# Altium schematic sheet Sheet.SchDoc
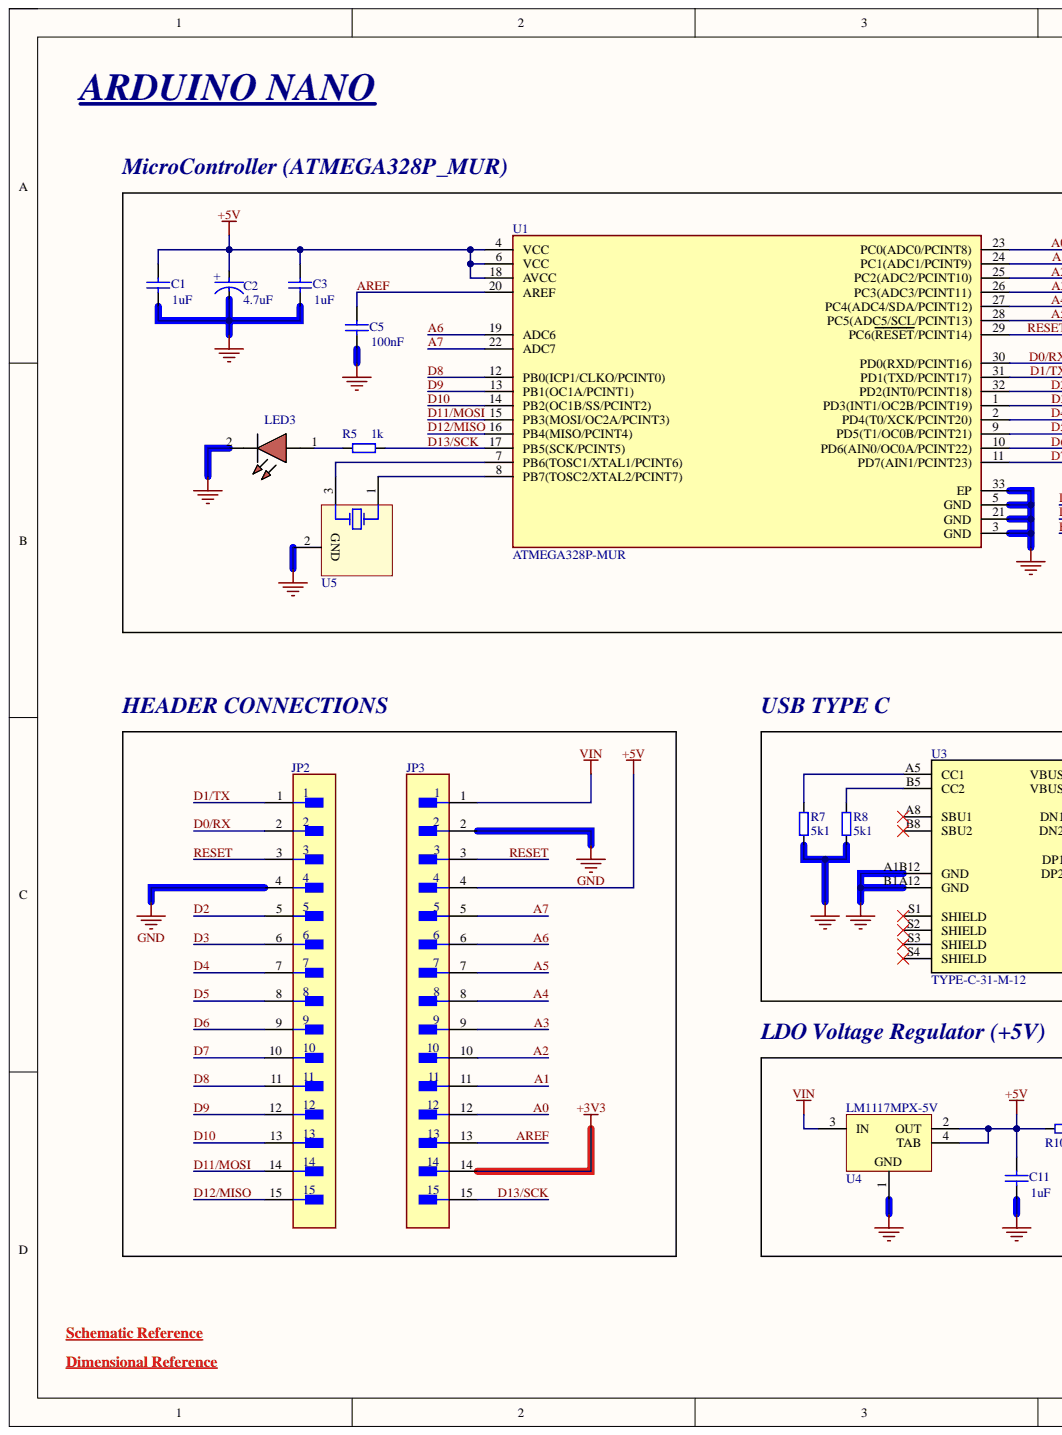
[diagram p0: Sheet.SchDoc, left]
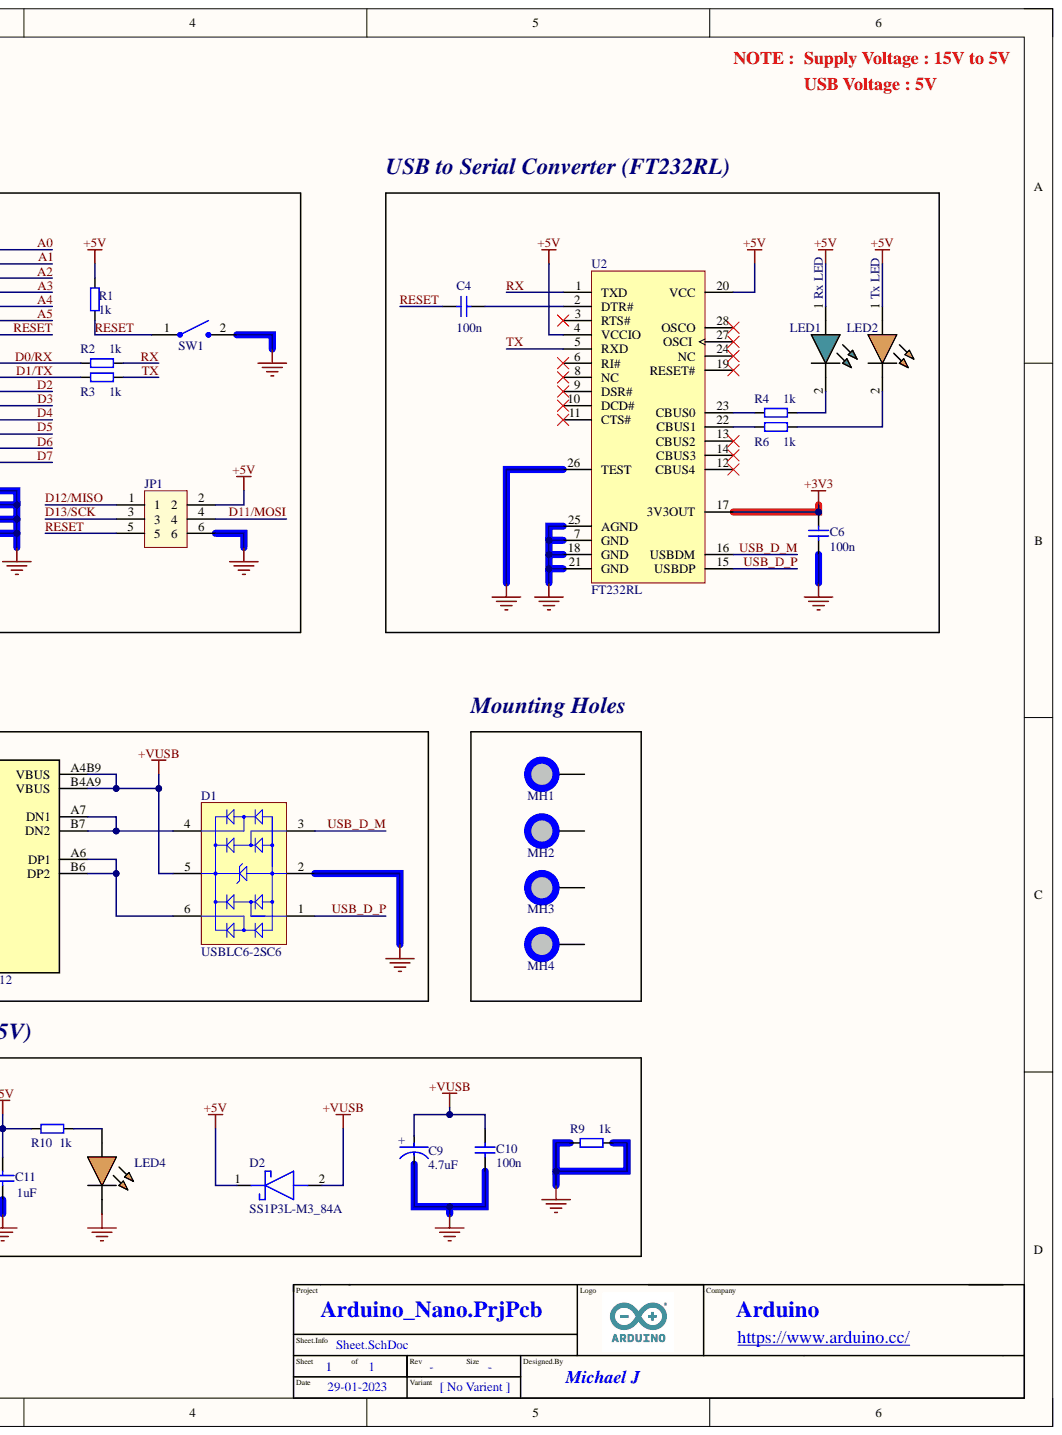
[diagram p1: Sheet.SchDoc, right]

## Parts
C1  value 1uF  [0402]  (hidden comment: C0402)
C2  4.7uF  [FP-T491B475K016AT-MFG]
C3  value 1uF  [0402]  (hidden comment: C0402)
C4  100n  [CAP_0402_16V]
C5  value 100nF  [0402]  (hidden comment: C0402)
C6  100n  [CAP_0402_16V]
C9  4.7uF  [FP-T491B475K016AT-MFG]
C10  100n  [CAP_0402_16V]
C11  value 1uF  [0402]  (hidden comment: C0402)
D1  USBLC6-2SC6  [STM-SOT23-6L_V]
D2  SS1P3L-M3_84A  [SS1P3LM384A]
JP1  Header_Male 2x03 2.54mm  [Header_Male 2x03 2.54mm]  (value not drawn)
JP2  TSW-115-07-G-S  [FP-TSW-115-07-G-S-MFG]  (value not drawn)
JP3  TSW-115-07-G-S  [FP-TSW-115-07-G-S-MFG]  (value not drawn)
LED1  LED_0402_GREEN  [LED_0402]  (value not drawn)
LED2  LED_0402_ORANGE  [LED_0402]  (value not drawn)
LED3  LED_0402_ORANGE  [LED_0402]  (value not drawn)
LED4  LED_0402_ORANGE  [LED_0402]  (value not drawn)
MH1  Mounting_Hole_NP_7.4mm_3.2mmNPD  [Mounting_Hole_NP_7.4mm_3.2mmNPD]  (value not drawn)  (no BOM)
MH2  Mounting_Hole_NP_7.4mm_3.2mmNPD  [Mounting_Hole_NP_7.4mm_3.2mmNPD]  (value not drawn)  (no BOM)
MH3  Mounting_Hole_NP_7.4mm_3.2mmNPD  [Mounting_Hole_NP_7.4mm_3.2mmNPD]  (value not drawn)  (no BOM)
MH4  Mounting_Hole_NP_7.4mm_3.2mmNPD  [Mounting_Hole_NP_7.4mm_3.2mmNPD]  (value not drawn)  (no BOM)
R1  1k  [RES_0402]
R2  1k  [RES_0402]
R3  1k  [RES_0402]
R4  1k  [RES_0402]
R5  1k  [RES_0402]
R6  1k  [RES_0402]
R7  5k1  [RES_0402]
R8  5k1  [RES_0402]
R9  1k  [RES_0402]
R10  1k  [RES_0402]
SW1  SMD,3x4x2.5mm Tactile Switches ROHS  [SMD,3x4x2.5mm Tactile Switches ROHS]  (value not drawn)
U1  ATMEGA328P-MUR  [FP-32M1-A-IPC_C]
U2  FT232RL  [FTDI-SSOP-28_M]
U3  TYPE-C-31-M-12  [TYPE-C-31-M-12]
U4  LM1117MPX-5V  [DCY0004A_L]
U5  CSTCE16M0V53-R0  [MURA-CSTCE_V-3_V]  (value not drawn)

## Nets
+3V3: C6.2 JP3.14 U2.17(3V3OUT)
+5V: C1.2 C2.2 C3.2 C11.2 D2.1 JP1.2 JP3.4 LED1.1 LED2.1 R1.2 R10.2 U1.4(VCC) U1.6(VCC) U1.18(AVCC) U2.4(VCCIO) U2.20(VCC) U4.2(OUT) U4.4(TAB)
+VUSB: C9.2 C10.1 D1.5 D2.2 U3.A4B9(VBUS) U3.B4A9(VBUS)
GND: C1.1 C2.1 C3.1 C5.1 C6.1 C9.1 C10.2 C11.1 D1.2 JP1.6 JP2.4 JP3.2 LED3.2 LED4.2 R7.1 R8.1 R9.1 R9.2 SW1.2 U1.3(GND) U1.5(GND) U1.21(GND) U1.33(EP) U2.7(GND) U2.18(GND) U2.21(GND) U2.25(AGND) U2.26(TEST) U3.A1B12(GND) U3.B1A12(GND) U4.1 U5.2
VIN: JP3.1 U4.3(IN)
A0: JP3.12 U1.23(PC0(ADC0/PCINT8))
A1: JP3.11 U1.24(PC1(ADC1/PCINT9))
A2: JP3.10 U1.25(PC2(ADC2/PCINT10))
A3: JP3.9 U1.26(PC3(ADC3/PCINT11))
A4: JP3.8 U1.27(PC4(ADC4/SDA/PCINT12))
A5: JP3.7 U1.28(PC5(ADC5/SCL/PCINT13))
A6: JP3.6 U1.19(ADC6)
A7: JP3.5 U1.22(ADC7)
AREF: C5.2 JP3.13 U1.20(AREF)
D0/RX: JP2.2 R2.2 U1.30(PD0(RXD/PCINT16))
D1/TX: JP2.1 R3.2 U1.31(PD1(TXD/PCINT17))
D2: JP2.5 U1.32(PD2(INT0/PCINT18))
D3: JP2.6 U1.1(PD3(INT1/OC2B/PCINT19))
D4: JP2.7 U1.2(PD4(T0/XCK/PCINT20))
D5: JP2.8 U1.9(PD5(T1/OC0B/PCINT21))
D6: JP2.9 U1.10(PD6(AIN0/OC0A/PCINT22))
D7: JP2.10 U1.11(PD7(AIN1/PCINT23))
D8: JP2.11 U1.12(PB0(ICP1/CLKO/PCINT0))
D9: JP2.12 U1.13(PB1(OC1A/PCINT1))
D10: JP2.13 U1.14(PB2(OC1B/SS/PCINT2))
D11/MOSI: JP1.4 JP2.14 U1.15(PB3(MOSI/OC2A/PCINT3))
D12/MISO: JP1.1 JP2.15 U1.16(PB4(MISO/PCINT4))
D13/SCK: JP1.3 JP3.15 R5.1 U1.17(PB5(SCK/PCINT5))
RESET: C4.1 JP1.5 JP2.3 JP3.3 R1.1 SW1.1 U1.29(PC6(RESET/PCINT14))
RX: R2.1 U2.1(TXD)
TX: R3.1 U2.5(RXD)
USB_D_M: D1.3 U2.16(USBDM)
USB_D_P: D1.1 U2.15(USBDP)
NetC4_2: C4.2 U2.2(DTR#)
NetD1_4: D1.4 U3.A7(DN1) U3.B7(DN2)
NetD1_6: D1.6 U3.A6(DP1) U3.B6(DP2)
NetLED1_2: LED1.2 R4.1
NetLED2_2: LED2.2 R6.1
NetLED3_1: LED3.1 R5.2
NetLED4_1: LED4.1 R10.1
NetR4_2: R4.2 U2.23(CBUS0)
NetR6_2: R6.2 U2.22(CBUS1)
NetR7_2: R7.2 U3.A5(CC1)
NetR8_2: R8.2 U3.B5(CC2)
NetU1_7: U1.7(PB6(TOSC1/XTAL1/PCINT6)) U5.3
NetU1_8: U1.8(PB7(TOSC2/XTAL2/PCINT7)) U5.1

Not connected: MH1 1; MH2 1; MH3 1; MH4 1; U2 3(RTS#) 6(RI#) 8(NC) 9(DSR#) 10(DCD#) 11(CTS#) 12(CBUS4) 13(CBUS2) 14(CBUS3) 19(RESET#) 24(NC) 27(OSCI) 28(OSCO); U3 A8(SBU1) B8(SBU2) S1(SHIELD) S2(SHIELD) S3(SHIELD) S4(SHIELD)

## Library data of the parts
C1 C3 C5 C11 = C0402: Ceramic Chip Capacitor - Standard; Note: Footprint corresponds to dimensions for bulk cassette packaging. This case size has tightened tolerances.; Code_IPC: 1005 [0402]; Code_JEITA: 0402; Component Kind: Standard; ComponentLink1Description: Manufacturer Link; ComponentLink1URL: http://www.kemet.com/; ComponentLink2Description: Datasheet; ComponentLink2URL: http://www.kemet.com/kemet/web/homepage/kechome.nsf/vapubfilesname/F3102TA.pdf/$file/F3102TA.pdf; DatasheetDocument: Mar-2001; LatestRevisionDate: 19-Mar-2004; LatestRevisionNote: Silkscreen size reduced.; Library Name: KEMET Chip Capacitor.IntLib; PackageDocument: Mar-2001; PackageReference: 0402; Published: 17-Jul-2002; Signal Integrity: C0402; Simulation: CAP; Publisher: Altium Limited
C2 C9 = CMP-03017-000048-1: CAP TANT 4.7UF 10% 16V 1411, T491B475K016AT; Dielectric Material: Tantalum; Dissipation Factor: 6%; ESR (Equivalent Series Resistance): 3.5R; Leakage Current: 800nA; Life (Hours): 2000Hour; Polarity: Polar; Tolerance: 20%; Capacitance: 4.7uF; Case Code (Imperial): 1411; Case Code (Metric): 3528; Case/Package: 1411; Component Kind: Standard; Depth: 2.8mm; Features: General Purpose; Height: 1.9mm; Height - Seated (Max): 0.083inch; Lead Free: Lead Free; Lead Pitch: 1.9mm; Length: 3.5mm; Library Name: Altium Content Vault; Max Operating Temperature: 125°C; Min Operating Temperature: -55°C; Mount: Surface Mount; Number of Pins: 2; Package Quantity: 1; Packaging: Tape and Reel; Radiation Hardening: No; REACH SVHC: No SVHC; RoHS Compliant: Yes; Termination: SMD/SMT; Voltage: 16V; Voltage Rating: 16V; Voltage Rating (DC): 16V; Width: 2.8mm
C4 C6 C10 = CAP_0402_16V: Capacitor SMD 0402 16V; Component Kind: Standard; Library Name: Prezence_SMD.IntLib
D1 = CMP-2000-05378-1: Very low capacitance ESD protection, 3.5 pF, -40 to 125 degC, 6-Pin SOT23, RoHS, Tape and Reel; Manufacturer: STMicroelectronics; Capacitance Value: 3.5pF; Clamping Voltage: 17V; Configuration: Dual; Direction Type: Uni-Directional; Leakage Current: 0.15uA; Number of Elements: 1; Peak Pulse Current: 5A; Product Depth (mm): 1.75mm; Product Height (mm): 1.3mm; Product Length (mm): 3.05mm; Rad Hardened: No; Reverse Breakdown Voltage: 6V; Reverse Stand-off Voltage: 5V; Suppressor Type: DiodeArrays; Test Current (It): 1mA; Clamping Voltage (Max): 17V; Component Kind: Standard; Datasheet URL: http://www.st.com/st-web-ui/static/active/en/resource/technical/document/datasheet/CD00050750.pdf; Datasheet Version: Rev. 5, 10/2011; Leakage Current (Max): 0.15uA; Library Name: Altium Content Vault; Manufacturer URL: http://www.st.com/; Mounting: SurfaceMount; Mounting Style: SurfaceMount; Operating Temp Range: -40Cto125C; Operating Temperature Classification: Automotive; Operating Temperature Max Deg. C: 125C; Operating Temperature Min Deg. C: -40C; Package / Case: SOT-23; Package Description: 6-Pin Small Outline Transistor, Body 2.925 x 1.625 mm, Pitch 0.95 mm; Package Reference: SOT23-6L; Packaging: TapeandReel; Pin Count: 6; Polarity: Uni-Directional; Working Voltage: 5V; Working Voltage (Max): 5V
D2 = SS1P3L-M3_84A: Schottky Diode; Manufacturer_Name: Vishay; Manufacturer_Part_Number: SS1P3L-M3/84A; Arrow Part Number: SS1P3L-M3/84A; Arrow Price/Stock: https://www.arrow.com/en/products/ss1p3l-m384a/vishay?region=nac; Component Kind: Standard; Datasheet Link: https://ms.componentsearchengine.com/Datasheets/2/SS1P3L-M3_84A.pdf; Height: 1.15mm; Mouser Part Number: 625-SS1P3L-M3/84A; Mouser Price/Stock: https://www.mouser.co.uk/ProductDetail/Vishay-General-Semiconductor/SS1P3L-M3-84A?qs=HSPD0Bff7iZtYrbeCvAZDQ%3D%3D
JP1 = Header_Male 2x03 2.54mm: Conn Unshrouded Header HDR 6 POS 2.54mm Solder ST Thru-Hole; Manufacturer: Samtec; Manufacturer Part Number: TSW-103-07-F-D; Connector Type: Male Pin; Gender: Male; Max Current Rating: 7.6A; Max Voltage Rating (AC): 550V; Number of Contacts: 6; Row Spacing: 0.1inch; Category: Headers and Wire Housings; Color: Black; Component Kind: Standard; ComponentLink1Description: https://datasheet.ciiva.com/739/tsw-xxx-xx-xxx-x-xx-xxx-mkt-739891.pdf?src-supplier=Digi-Key; ComponentLink1URL: https://datasheet.ciiva.com/739/tsw-xxx-xx-xxx-x-xx-xxx-mkt-739891.pdf?src-supplier=Digi-Key; ComponentLink2Description: https://datasheet.ciiva.com/16722/htsw-th-16722939.pdf?src-supplier=Avnet+Europe; ComponentLink2URL: https://datasheet.ciiva.com/16722/htsw-th-16722939.pdf?src-supplier=Avnet+Europe; Contact Material: Bronze; Contact Mating Length: 0.23inch; Contact Plating: Gold; Contact Type: Male Pin; Depth: 5.08mm; Housing Color: Black; Housing Material: Polyester; Length: 7.62mm; Library Name: Arduino_Uno_V1.1.IntLib; Max Operating Temperature: 125°C; Min Operating Temperature: -55°C; Mount: Through Hole; Number of Positions: 6; Number of Rows: 2; Orientation: Straight; Packaging: Bulk; Pitch: 2.54mm; Pricing 1: 1=0.71000, 10=0.66700, 100=0.51110, 500=0.44440, 1000=0.36663, 5000=0.32219, 10000=0.29997 (USD); Radiation Hardening: No; REACH SVHC: No SVHC; RoHS: Yes; RoHS Compliant: Yes; Series: TSW; Stock 1: 13135; Supplier 1: Digi-Key; Supplier Part Number 1: SAM10846-ND; Termination: Solder
JP2 JP3 = CMP-02766-000090-1: CONN HEADER VERT 15POS 2.54MM; Manufacturer: SAMTEC; Conn Gender: Male; Conn Orientation: Vertical; Number Of Contacts: 15; Automotive: No; Category: Conn; Component Kind: Standard; ComponentLink2Description: Datasheet; ComponentLink2URL: http://suddendocs.samtec.com/catalog_english/tsw_th.pdf; Contact Material: Gold,Nickel; Device Class L1: Connectors; Device Class L2: Headers and Wire Housings; Device Class L3: unset; Digikey Description: CONN HEADER VERT 15POS 2.54MM; Footprint Url: http://suddendocs.samtec.com/prints/tsw-xxx-xx-x-x-xx-xxx-footprint.pdf; Height: 8.71mm; Lead Free: Yes; Library Name: Altium Content Vault; Manufacturer Part Number: TSW-115-07-G-S; Number Of Rows: 1; Octopart: https://octopart.com/tsw-115-07-g-s-samtec-272210; Package: TSW-115-07-G-S; Pitch: 2.54mm; RoHS: TRUE; Temperature: -55°C to +125°C; Temperature Range High: +125°C; Temperature Range Low: -55°C
LED1 = LED_0402_GREEN: LED SMD 0402 Green; Manufacturer_Name: Kingbright; Manufacturer_Part_Number: APHHS1005LZGCK-V; Arrow Part Number: APHHS1005LZGCK-V; Arrow Price/Stock: https://www.arrow.com/en/products/aphhs1005lzgck-v/kingbright; Component Kind: Standard; Datasheet Link: https://www.kingbrightusa.com/images/catalog/SPEC/APHHS1005LZGCK-V.pdf; Height: 0.6mm; Mouser Part Number: N/A; Mouser Price/Stock: https://www.mouser.co.uk/ProductDetail/Kingbright/APHHS1005LZGCK-V?qs=HXFqYaX1Q2zaZg1%252BPZjK%2FQ%3D%3D; Library Name: Prezence_SMD.IntLib
LED2-LED4 = LED_0402_ORANGE: LED SMD 0402 Orange; Component Kind: Standard; Library Name: Prezence_SMD.IntLib
MH1-MH4 = Mounting_Hole_NP_7.4mm_3.2mmNPD: Mounting_Hole_NonPlated_7.4mm_3.2mmNonPlatedDrill; Library Name: Prezence_SMD.IntLib
R1-R6 R9 R10 = RES_0402_2: Resistor SMD 0402; Component Kind: Standard; Library Name: Prezence_SMD.IntLib
R7 R8 = RES_0402_3: Resistor SMD 0402; Component Kind: Standard; Library Name: Prezence_SMD.IntLib
U1 = CMP-06276-000062-1: IC MCU 8BIT 32KB FLASH 32VQFN; Core Architecture: PIC; Frequency: 20MHz; Interface: I2C; Memory Size: 3.5KB; Number of I/Os: 24; Oscillator Type: Internal; Peripherals: Brown-out Detect/Reset; Case/Package: VQFN; Component Kind: Standard; Library Name: Altium Content Vault; Max Operating Temperature: 125°C; Memory Type: FLASH; Min Operating Temperature: -40°C; Packaging: Rail/Tube
U2 = CMP-2000-05029-1: IC USB FS SERIAL UART 28-SSOP; Manufacturer: FTDI; Maximum Data Rate: 3MBd; Number of Channels per Chip: 1; Receive FIFO: 256B; Transmit FIFO: 128B; Component Kind: Standard; Datasheet URL: http://datasheet.octopart.com/FT232RL-FTDI-datasheet-120501.pdf; Datasheet Version: Rev. 1.04, 02/2006; Dimensions: 10.2x5.3x1.75mm; Height: 1.75mm; Length: 10.2mm; Library Name: Altium Content Vault; Manufacturer URL: http://www.ftdichip.com/; Maximum Operating Temperature: +85degC; Minimum Operating Temperature: -40degC; Mounting Type: SurfaceMount; Package Type: SSOP; Pin Count: 28; Width: 5.3mm
U3 = USB_Type_C: Component Kind: Standard; Description: TYPE-C-31-M-12; Library Name: Prezence_Final.IntLib
U4 = CMP-0062-01635-4: 800mA Low-Dropout Linear Regulator, 4-pin SOT-223, Pb-Free; Manufacturer: Texas Instruments; PartNumber: LM1117MPX-5.0/NOPB; CompType: LDO IC; High-Reliability: Y; PackageTopMarking: XYTT*N06A; PowerWise: N; Code_IPC: SOT223-4; Code_JEDEC: TO-261-AA; Component Kind: Standard; ComponentLink1Description: Datasheet URL; ComponentLink1URL: http://www.ti.com/general/docs/lit/getliterature.tsp?genericPartNumber=LM1117-N&fileType=pdf; Library Name: Altium Content Vault; PackageDescription: Molded SOT-223, 6.50 x 3.56 x 1.6mm Body, 4 Lead, 2.3mm Pitch; PackageReference: DCY0004A; PinCount: 4; RoHS: Y
U5 = CMP-0588-00001-2: Ceramic Resonator, 16 MHz with Built-in Capacitance, Thickness Expander Mode, Initial Frequency Tolerance +/- 0.5%, Load Capacity 15 pF, -20 to 80 degC, 3.2 x 1.3 x 1 mm SMD, RoHS, Reel; Manufacturer: Murata; Load Capacity: 15 pF; Oscillating Frequency: 16 MHz; Component Kind: Standard; ComponentLink1Description: Manufacturer URL; ComponentLink1URL: http://www.murata.com/; ComponentLink2Description: Datasheet; ComponentLink2URL: http://www.murata.com/products/catalog/pdf/p16e.pdf; DatasheetVersion: Rev. P16E-22; Initial Frequency Tolerance: +/- 0.5%; Initial Tolerance: +/- 0.5%; Library Name: Altium Content Vault; Mounting Technology: Surface Mount; Operating Temperature Range: -20 to 80 degC; PackageDescription: 3-Pin SMD, Body 3.2 x 1.3 mm, Pitch 0.95 mm; PackageReference: CSTCE_V-3; Packing: Reel; PartNumber: CSTCE16M0V53-R0; RoHS: TRUE
SW1 = SMD,3x4x2.5mm Tactile Switches ROHS: Component Kind: Standard; Library Name: Prezence_SMD.IntLib
